# Altium schematic sheet LED strip.SchDoc("LED strip")
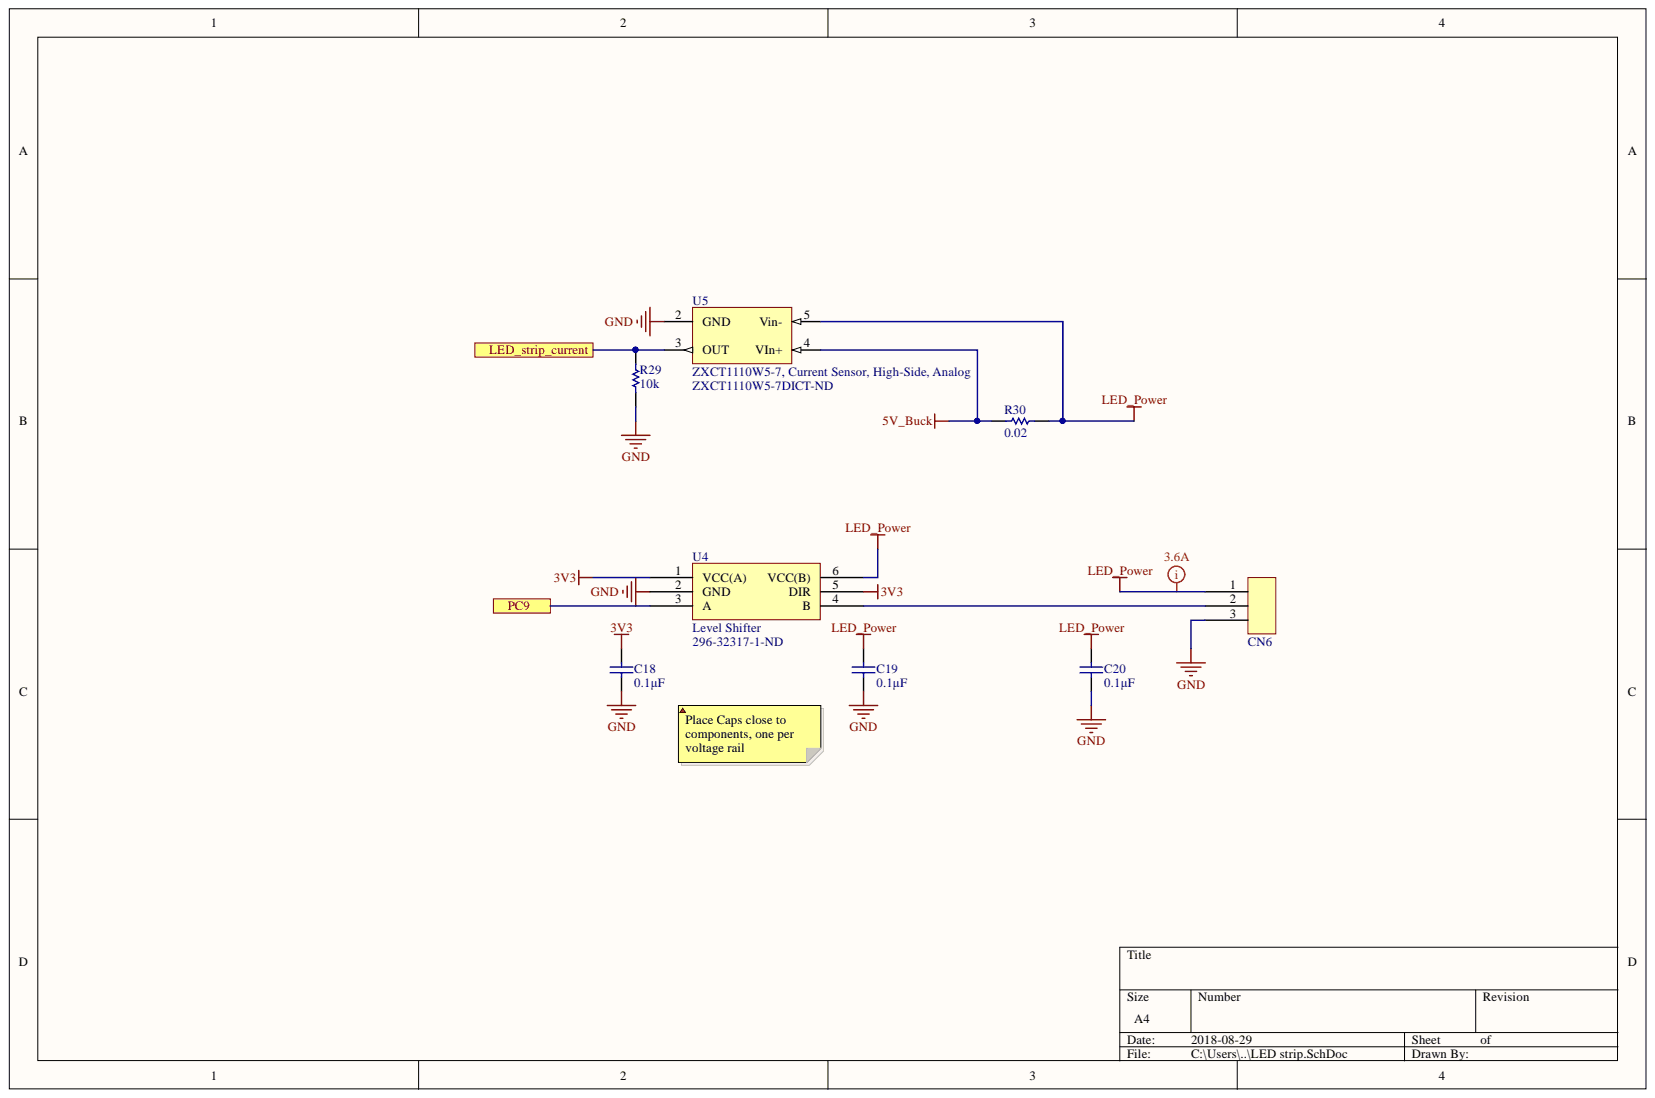
[diagram p0: LED strip.SchDoc]

## Parts
C18  0.1μF  [CAPC1608N(0603")]
C19  0.1μF  [CAPC1608N(0603")]
C20  0.1μF  [CAPC1608N(0603")]
CN6  B3PS-VH(LF)(SN)  [3X1 Male Header, Locking, 10A]  (value not drawn)
R29  10k  [RESC1608N(0603")]
R30  0.02  [RESC6332N(2512")]
U4  Level Shifter  Supplier Part Number 1: 296-32317-1-ND  [SOT-363]
U5  ZXCT1110W5-7, Current Sensor, High-Side, Analog  Supplier Part Number 1: ZXCT1110W5-7DICT-ND  [SOT23-5]

## Ports
LED_strip_current, PC9

## Nets
3V3: C18.1 U4.1(VCC(A)) U4.5(DIR)
5V_Buck: R30.1 U5.4(VIn+)
GND: C18.2 C19.2 C20.2 CN6.3 R29.1 U4.2(GND) U5.2(GND)
LED_Power: C19.1 C20.1 CN6.1 R30.2 U4.6(VCC(B)) U5.5(Vin-)
LED_strip_current: R29.2 U5.3(OUT) ; port LED_strip_current
PC9: U4.3(A) ; port PC9
NetCN6_2: CN6.2 U4.4(B)

## Library data of the parts
C18-C20 = 0.1uF 16V 0603 SMD, Ceramic: Capacitor, Ceramic, 16V, +/- 10%, 0603 SMD, X7R; Value: 0.1μF; Supplier Part Number 1: 1276-1005-1-ND; Supplier 1: Digi-Key; Published: 16-04-26; PackageDescription: Chip Capacitor; Component Kind: Standard; Publisher: AUVic; Library Name: AUVic_Integrated_Library_Capacitors.IntLib; Created By: Andy Bates
CN6 = 3x1 Male Header, Locking, 10A: CONN HEADER VH SIDE 3POS 3.96MM; Made by: Robert Keen; Supplier Part Number 3: 455-1133-1-ND; Supplier Part Number 2: 455-1184-ND; Supplier Part Number 1: 455-1649-ND; Supplier 1: Digi-Key; Made on: 2018-08-16; ComponentLink3URL: https://www.digikey.ca/product-detail/en/jst-sales-america-inc/SVH-21T-P1.1/455-1133-1-ND/527367; ComponentLink3Description: Digikey (crimps); ComponentLink2URL: https://www.digikey.ca/product-detail/en/jst-sales-america-inc/VHR-3N/455-1184-ND/608625; ComponentLink2Description: Digikey (female); ComponentLink1URL: https://www.digikey.ca/product-detail/en/jst-sales-america-inc/B3PS-VH-LF-SN/455-1649-ND/926556; ComponentLink1Description: Digikey (male); Component Kind: Standard; Library Name: AUVic_Integrated_Library_Connectors.IntLib
R29 = 10kR, 1%, 1/10W, 0603 SMD: Owned By: AUVic; Made By: Ashwin Sira; Value: 10k; Supplier Part Number 1: 311-10.0KHRCT-ND; Supplier 1: Digi-Key; Library Name: AUVic_Integrated_Library_Resistors.IntLib; Last Edited: 14-05-31; Description: 10kR, 1%, 1/10W, 0603 SMD; Created On: 14-05-31; Component Kind: Standard
R30 = 0R02, 1%, 2W, 2512 SMD: Value: 0.02; Supplier Part Number 1: A109677CT-ND; Supplier 1: Digi-Key; Description: 0R02, 1%, 2W, 2512 SMD; Created On: 2018-08-16; ComponentLink1URL: https://www.digikey.ca/product-detail/en/te-connectivity-passive-product/RLP73V3AR020FTDF/A109677CT-ND/4032443; ComponentLink1Description: Digikey; Component Kind: Standard; Library Name: AUVic_Integrated_Library_Resistors.IntLib; Created By: Robert Keen
U4 = Bidirectional Level Shifter, 1.65V - 5.5V VCCA, 1.65V - 5.5V VCCB: Voltage Level Translator Bidirectional 1 Circuit 1 Channel 420Mbps SC-88 (SOT-363); Made By: Andy Bates; Supplier 1: Digi-Key; Made on: 2018-08-16; ComponentLink1URL: https://www.digikey.ca/product-detail/en/nxp-usa-inc/NTB0101AGWH/568-14541-1-ND/9449849; ComponentLink1Description: Digikey; Component Kind: Standard; Library Name: AUVic_Integrated_Library_Logic.IntLib
U5 = ZXCT1110W5-7, Current Sensor, High-Side, Analog: Diodes Incorporated, ZXCT1110W5-7; Supplier 1: Digi-Key; Created On: 2016-03-16; Component Kind: Standard; Publisher: AUVic; Library Name: AUVic_Schematic_Library_Sensors.SchLib; Created By: Jacob Lower
